# Altium schematic sheet 2_LPC4088.SchDoc
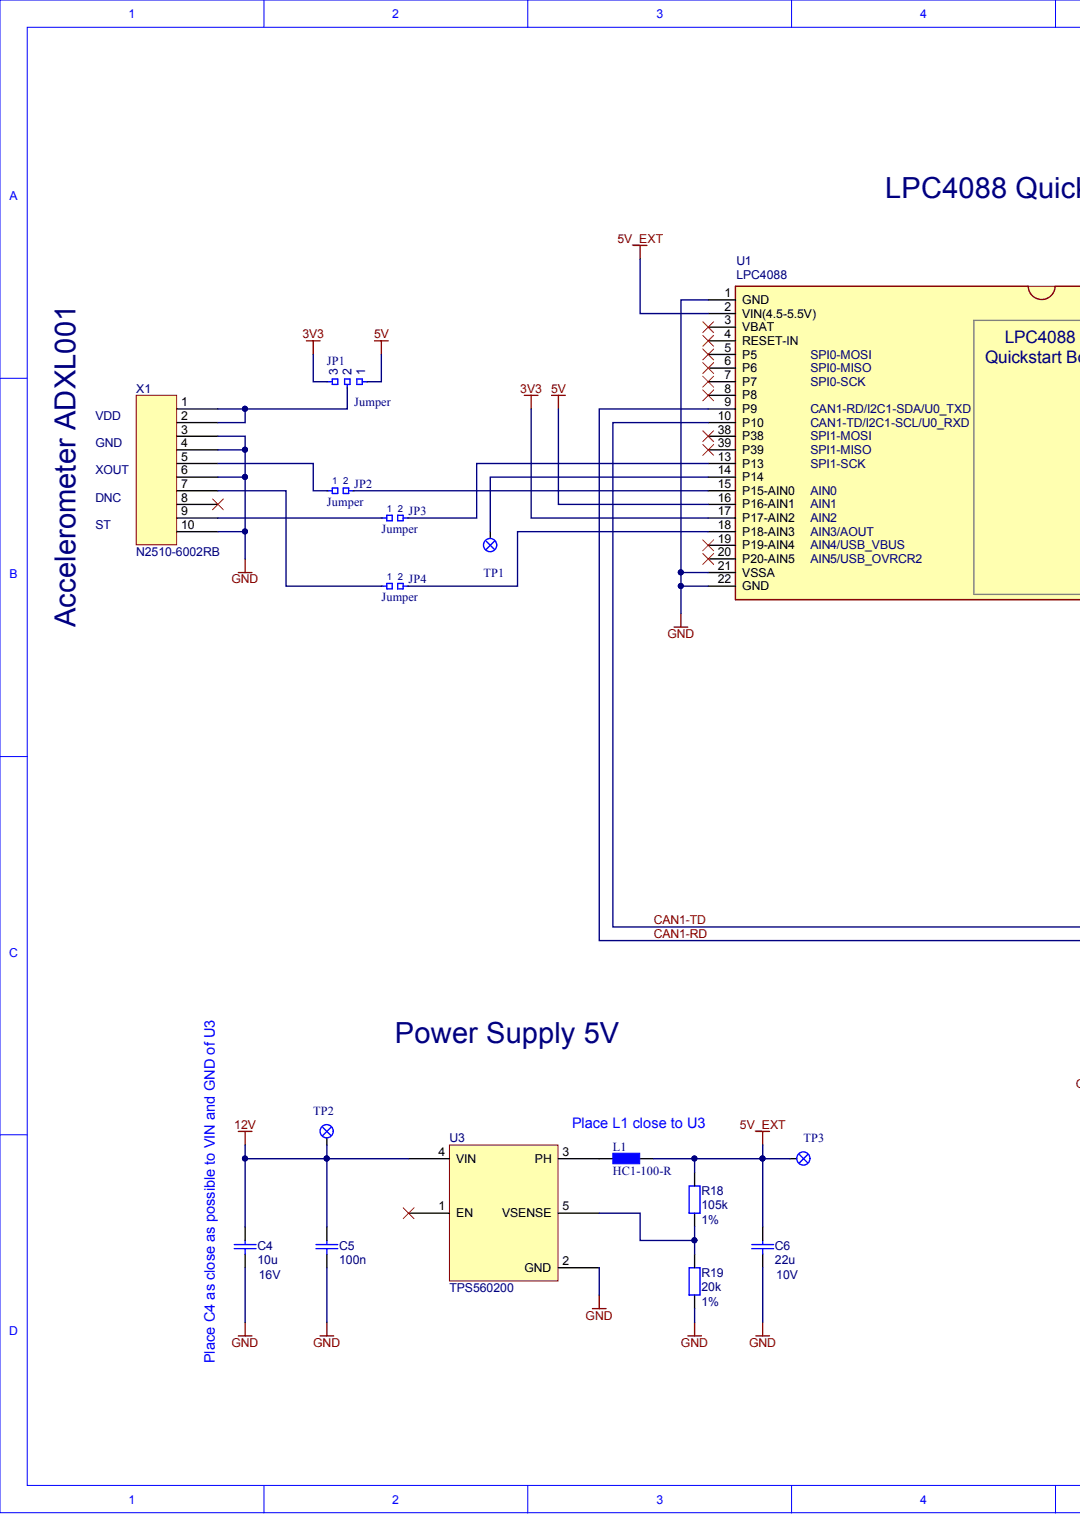
[diagram p0: 2_LPC4088.SchDoc, left]
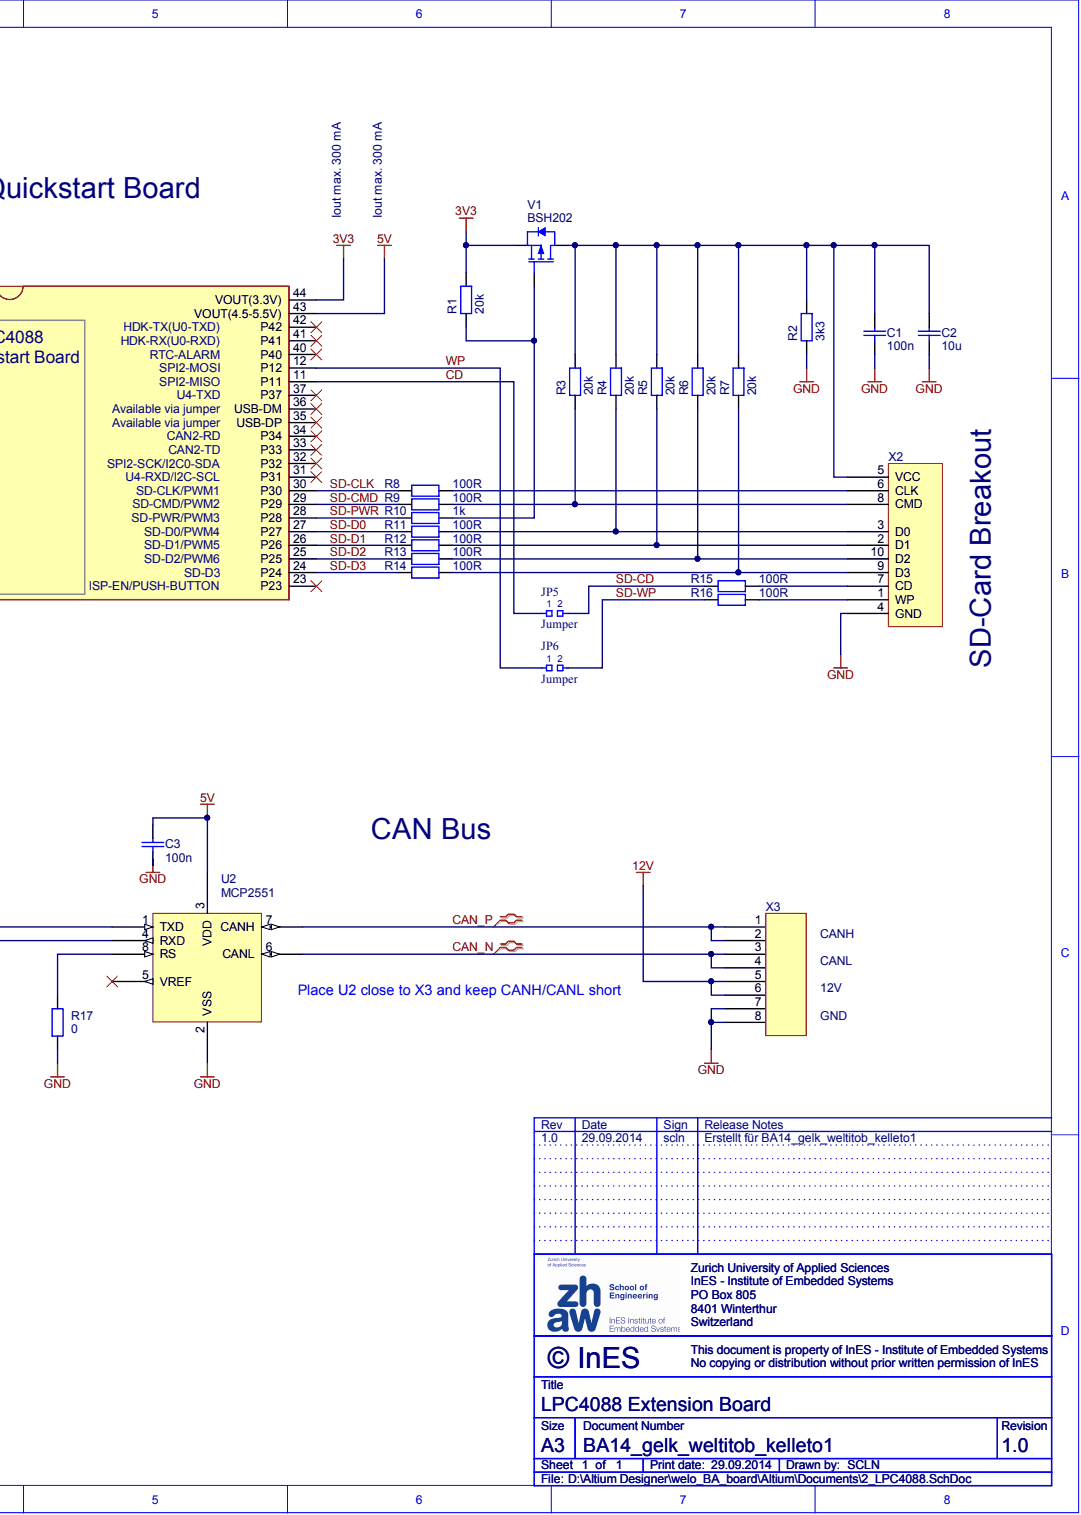
[diagram p1: 2_LPC4088.SchDoc, right]

## Parts
C1  100n  [CHIP 0603]
C2  10u  [CHIP 1206]
C3  100n  [CHIP 0603]
C4  10u  VDC: 16V  [CHIP 1206]
C5  100n  [CHIP 0805]
C6  22u  VDC: 10V  [CHIP 1206]
JP1  Jumper  [JMP 1X3]
JP2  Jumper  [JMP 1X2]
JP3  Jumper  [JMP 1X2]
JP4  Jumper  [JMP 1X2]
JP5  Jumper  [JMP 1X2]
JP6  Jumper  [JMP 1X2]
L1  HC1-100-R  [WE-PD-M]
R1  20k  [CHIP 0603]
R2  3k3  [CHIP 0603]
R3  20k  [CHIP 0603]
R4  20k  [CHIP 0603]
R5  20k  [CHIP 0603]
R6  20k  [CHIP 0603]
R7  20k  [CHIP 0603]
R8  100R  [CHIP 0603]
R9  100R  [CHIP 0603]
R10  1k  [CHIP 0603]
R11  100R  [CHIP 0603]
R12  100R  [CHIP 0603]
R13  100R  [CHIP 0603]
R14  100R  [CHIP 0603]
R15  100R  [CHIP 0603]
R16  100R  [CHIP 0603]
R17  0  [CHIP 0603]
R18  105k  Tolerance: 1%  [CHIP 0402]
R19  20k  Tolerance: 1%  [CHIP 0603]
TP1  TP  [CON 1X1]  (no BOM)
TP2  TP  [CON 1X1]  (no BOM)
TP3  TP  [CON 1X1]  (no BOM)
U1  LPC4088  [LPC4088_QUICKSTART_BOARD_REV-A]
U2  MCP2551  [PDIP8]
U3  TPS560200  [SOT23127P600-8N]
V1  BSH202  [SOT95P245X110-3N]
X1  N2510-6002RB  [HDR 100 MIL 2X5]
X2  SD-Card  [SD-Card]
X3  Schraubklemme  [KLEMME 8 X 5.08]  (value not drawn)

## Nets
3V3: JP1.3 R1.1 U1.17(P17-AIN2) U1.44(VOUT(3.3V)) V1.2
5V: C3.2 JP1.1 U1.16(P16-AIN1) U1.43(VOUT(4.5-5.5V)) U2.3(VDD)
5V_EXT: C6.2 L1.2 R18.2 TP3.1 U1.2(VIN(4.5-5.5V))
12V: C4.2 C5.2 TP2.1 U3.4(VIN) X3.5 X3.6
GND: C1.1 C2.1 C3.1 C4.1 C5.1 C6.1 R2.2 R17.1 R19.1 U1.1(GND) U1.21(VSSA) U1.22(GND) U2.2(VSS) U3.2(GND) X1.3 X1.4 X1.6 X1.10 X2.4(GND) X3.7 X3.8
CAN1-RD: U1.9(P9) U2.4(RXD)
CAN1-TD: U1.10(P10) U2.1(TXD)
CAN_N: U2.6(CANL) X3.3 X3.4
CAN_P: U2.7(CANH) X3.1 X3.2
CD: JP5.1 U1.11(P11)
SD-CD: JP5.2 R15.2
SD-CLK: R8.2 U1.30(P30)
SD-CMD: R9.2 U1.29(P29)
SD-D0: R11.2 U1.27(P27)
SD-D1: R12.2 U1.26(P26)
SD-D2: R13.2 U1.25(P25)
SD-D3: R14.2 U1.24(P24)
SD-PWR: R10.2 U1.28(P28)
SD-WP: JP6.2 R16.2
WP: JP6.1 U1.12(P12)
NetC1_2: C1.2 C2.2 R2.1 R3.1 R4.1 R5.1 R6.1 R7.1 V1.3 X2.5(VCC)
NetJP1_2: JP1.2 X1.1 X1.2
NetJP2_1: JP2.1 X1.5
NetJP2_2: JP2.2 U1.15(P15-AIN0)
NetJP3_1: JP3.1 X1.9
NetJP3_2: JP3.2 U1.13(P13)
NetJP4_1: JP4.1 X1.7
NetJP4_2: JP4.2 U1.18(P18-AIN3)
NetL1_1: L1.1 U3.3(PH)
NetR1_2: R1.2 R10.1 V1.1
NetR3_2: R3.2 R9.1 X2.8(CMD)
NetR4_2: R4.2 R11.1 X2.3(D0)
NetR5_2: R5.2 R12.1 X2.2(D1)
NetR6_2: R6.2 R13.1 X2.10(D2)
NetR7_2: R7.2 R14.1 X2.9(D3)
NetR8_1: R8.1 X2.6(CLK)
NetR15_1: R15.1 X2.7(CD)
NetR16_1: R16.1 X2.1(WP)
NetR17_2: R17.2 U2.8(RS)
NetR18_1: R18.1 R19.2 U3.5(VSENSE)
NetTP1_1: TP1.1 U1.14(P14)

Not connected: U1 3(VBAT) 4(RESET-IN) 5(P5) 6(P6) 7(P7) 8(P8) 19(P19-AIN4) 20(P20-AIN5) 23(P23) 31(P31) 32(P32) 33(P33) 34(P34) 35(USB-DP) 36(USB-DM) 37(P37) 38(P38) 39(P39) 40(P40) 41(P41) 42(P42); U2 5(VREF); U3 1(EN); X1 8

## Library data of the parts
C1 C3 = C 50V: Capacitor 50V; Manufacturer: AVX; Class: 63 Capacitor; Component: 08053C104KAT2A; Dist Order No: 1689106; Dist Order No 2: 581-08053C104K; VDC: 25V; Component Kind: Standard; Distributor: Farnell; Distributor 2: Mouser; Man Order No: 08053C104KAT2A; Library Name: 60 Passiv.SchLib
C2 = C 50V: Capacitor 50V; Manufacturer: TDK; Class: 63 Capacitor; Component: C3216X5R1C106K160AA; Dist Order No: 2346949; Dist Order No 2: 810-C3216X5R1C106K; VDC: 16V; Component Kind: Standard; Distributor: Farnell; Distributor 2: Mouser; Man Order No: C3216X5R1C106K160AA; Library Name: 60 Passiv.SchLib
C4 = C 50V: KONDENSATOR, MLCC, 10UF, 16V, X5R; Manufacturer: TDK; Class: 63 Capacitor; Component: C3216X5R1C106K160AA; Dist Order No: 2346949; Dist Order No 2: 810-C3216X5R1C106K; Component Kind: Standard; Distributor: Farnell; Distributor 2: Mouser; Man Order No: C3216X5R1C106K160AA; Library Name: 60 Passiv.SchLib
C5 = C 50V: CAPACITOR CERAMIC, 0.1UF, 25V, X7R, 10%; Manufacturer: AVX; Class: 63 Capacitor; Component: 08053C104KAT2A; Dist Order No: 1689106; Dist Order No 2: 581-08053C104K; Component Kind: Standard; Distributor: Farnell; Distributor 2: Mouser; Man Order No: 08053C104KAT2A; VDC: 25V; Library Name: 60 Passiv.SchLib
C6 = C 50V: KONDENSATOR, 22UF, 1206, 10V, +/-20%; Manufacturer: TDK; Class: 63 Capacitor; Component: C3216X7S1A226M160AC; Dist Order No: 2211195; Dist Order No 2: 810-C3216X7S1A226M; Component Kind: Standard; Distributor: Farnell; Distributor 2: Mouser; Man Order No: C3216X7S1A226M160AC; Library Name: 60 Passiv.SchLib
JP1 = JMP 3: Jumper 3 Pol 100 mil; Manufacturer: Preci-Dip; Class: 71 Jumper; Component: Stiftleiste 8pol; Dist Order No: 122830; Man Order No: 890-18-008-10-803; Component Kind: Standard; Distributor: Distrelec; Library Name: 80 Stecker & Mech.SchLib
JP2-JP6 = JMP 2 H: Jumper 2 Pol 100 mil horizontal; Manufacturer: Preci-Dip; Class: 71 Jumper; Component: Stiftleiste 8pol; Dist Order No: 122830; Man Order No: 890-18-008-10-803; Component Kind: Standard; Distributor: Distrelec; Library Name: 80 Stecker & Mech.SchLib
L1 = L HC1-100-R: HC1-100-R - INDUKTIVITAET, POWER; Manufacturer: Coiltronics; Class: 65 Inductor; Dist Order No: 1612144; Dist Order No 2: 704-HC1-100-R; Component: HC1-100-R; Component Kind: Standard; Distributor: Farnell; Distributor 2: Mouser; Man Order No: HC1-100-R; Library Name: welo_PA_board.SchLib
R1 R3-R7 = R: Resistor; Manufacturer: Vishay; Class: 61 Resistor; Component: Widerstand SMD 20 kOhm ± 1 % 0603; Dist Order No: 715619; Dist Order No 2: 71-CRCW0603-20K-E3; Man Order No: CRCW060320K0FKEA; Component Kind: Standard; Distributor: Distrelec; Distributor 2: Mouser; Library Name: 60 Passiv.SchLib
R2 = R: Resistor; Manufacturer: Vishay; Class: 61 Resistor; Component: Widerstand SMD 3.3 kOhm ± 1 % 0603; Dist Order No: 715600; Dist Order No 2: 71-CRCW0603-3.3K-E3; Man Order No: CRCW06033K30FKEA; Component Kind: Standard; Distributor: Distrelec; Distributor 2: Mouser; Library Name: 60 Passiv.SchLib
R8 R9 R11-R16 = R: Resistor; Manufacturer: Vishay; Class: 61 Resistor; Component: Widerstand SMD 100 Ohm ± 1 % 0603; Dist Order No: 715564; Dist Order No 2: 71-CRCW0603-100-E3; Man Order No: CRCW0603100RFKEA; Component Kind: Standard; Distributor: Distrelec; Distributor 2: Mouser; Library Name: 60 Passiv.SchLib
R10 = R: Resistor; Manufacturer: Vishay; Class: 61 Resistor; Component: Widerstand SMD 1 kOhm ± 1 % 0603; Dist Order No: 715588; Dist Order No 2: 71-CRCW0603-1.0K-E3; Man Order No: CRCW06031K00FKEA; Component Kind: Standard; Distributor: Distrelec; Distributor 2: Mouser; Library Name: 60 Passiv.SchLib
R17 = R: Resistor; Manufacturer: Vishay; Class: 61 Resistor; Component: Widerstand SMD 0 Ohm ± 1 % 0603; Dist Order No: 715151; Dist Order No 2: 71-CRCW0603-0-E3; Man Order No: CRCW06030000Z0EA; Component Kind: Standard; Distributor: Distrelec; Distributor 2: Mouser; Library Name: 60 Passiv.SchLib
R18 = R: WIDERSTAND, 0402, 0.063W, 105K; Manufacturer: VISHAY DRALORIC; Class: 61 Resistor; Component: CRCW0402105KFKED; Dist Order No: 1692507; Dist Order No 2: 71-CRCW0402-105K-E3; Component Kind: Standard; Distributor: Distrelec; Distributor 2: Mouser; Man Order No: CRCW0402105KFKED; Library Name: 60 Passiv.SchLib
R19 = R: Resistor; Manufacturer: VISHAY DRALORIC; Class: 61 Resistor; Component: CRCW040220K0FKED; Dist Order No: 1469693; Dist Order No 2: 71-CRCW0402-20K-E3; Component Kind: Standard; Distributor: Distrelec; Distributor 2: Mouser; Man Order No: CRCW040220K0FKED; Library Name: 60 Passiv.SchLib
TP1-TP3 = TP: Testpunkt; Class: 71 Test-Punkt; Component: -; Dist Order No: -; Distributor: -; Man Order No: -; Manufacturer: -; Library Name: 80 Stecker & Mech.SchLib
U1 = LPC4088_QUICKSTART_BOARD_PERI_REV-A: LPC4088 Quickstart Board; Manufacturer: Preci-Dip; Class: 73 Stecker 100 mil; Component: Buchsenleiste 50pol; Dist Order No: 121562; Man Order No: 801-87-050-10-001101; Component Kind: Standard; Dist Order No 2: -; Distributor: Distrelec; Distributor 2: -; Library Name: welo_PA_board.SchLib
U2 = MCP2551: High-Speed CAN Transceiver; Manufacturer: MICROCHIP; Class: 34 Treiber; Component: MCP2551-E/P; Dist Order No: 1439745; Dist Order No 2: 579-MCP2551-E/P; Component Kind: Standard; Distributor: Farnell; Distributor 2: Mouser; Man Order No: MCP2551-E/P; Library Name: welo_PA_board.SchLib
U3 = TPS560200: DC/DC-WANDLER, 650KHZ, SOT23-5; Manufacturer: TEXAS INSTRUMENTS; Class: 24 Spannungsregler Switch; Dist Order No: 2373566; Dist Order No 2: 595-TPS560200DBVT; Man Order No: TPS560200DBVT; Component: TPS560200; Component Kind: Standard; Distributor: Farnell; Distributor 2: Mouser; Library Name: welo_PA_board.SchLib
V1 = BSH202: MOSFET P-CH 30V 520MA; Manufacturer: NXP Semiconductors; Class: 22 FET; Dist Order No: 1894740; Dist Order No 2: 568-8026-1-ND; Component: BSH202; Component Kind: Standard; Distributor: Farnell; Distributor 2: DigiKey; Man Order No: BSH202; Library Name: welo_PA_board.SchLib
X1 = CON PRINT 10 POL: Stiftleiste DIN 41651 10 P; Manufacturer: 3M; Class: 73 Stecker 100 mil; Dist Order No: 9838244; Dist Order No 2: 121400; Man Order No: N2510-6002RB.; Component: N2510-6002RB; Component Kind: Standard; Distributor: Farnell; Distributor 2: Distrelec; Library Name: welo_PA_board.SchLib
X2 = CON SD-Card: Manufacturer: Preci-Dip; Class: 73 Stecker 100mil; Component: Buchsenleiste 10pol; Dist Order No: 121550; Man Order No: 801-87-010-10-001101; Component Kind: Standard; Dist Order No 2: -; Distributor: Distrelec; Distributor 2: -; Library Name: welo_PA_board.SchLib
X3 = CON PRINT 8 POL: Printklemme 8 P5.08 mm, MKDSN 1,5-8-5,08, Phoenix Contact; Class: 75 Connector Schraubklemme; Dist Order No: 3041517; Dist Order No 2: 141219; Man Order No: 1729186; Component: Printklemme 8 P5.08 mm, MKDSN 1,5-8-5,08, Phoenix Contact; Component Kind: Standard; Distributor: Farnell; Distributor 2: Distrelec; Manufacturer: Phoenix Contact; Library Name: welo_PA_board.SchLib
